# Altium schematic sheet Minigeki v1.SchDoc
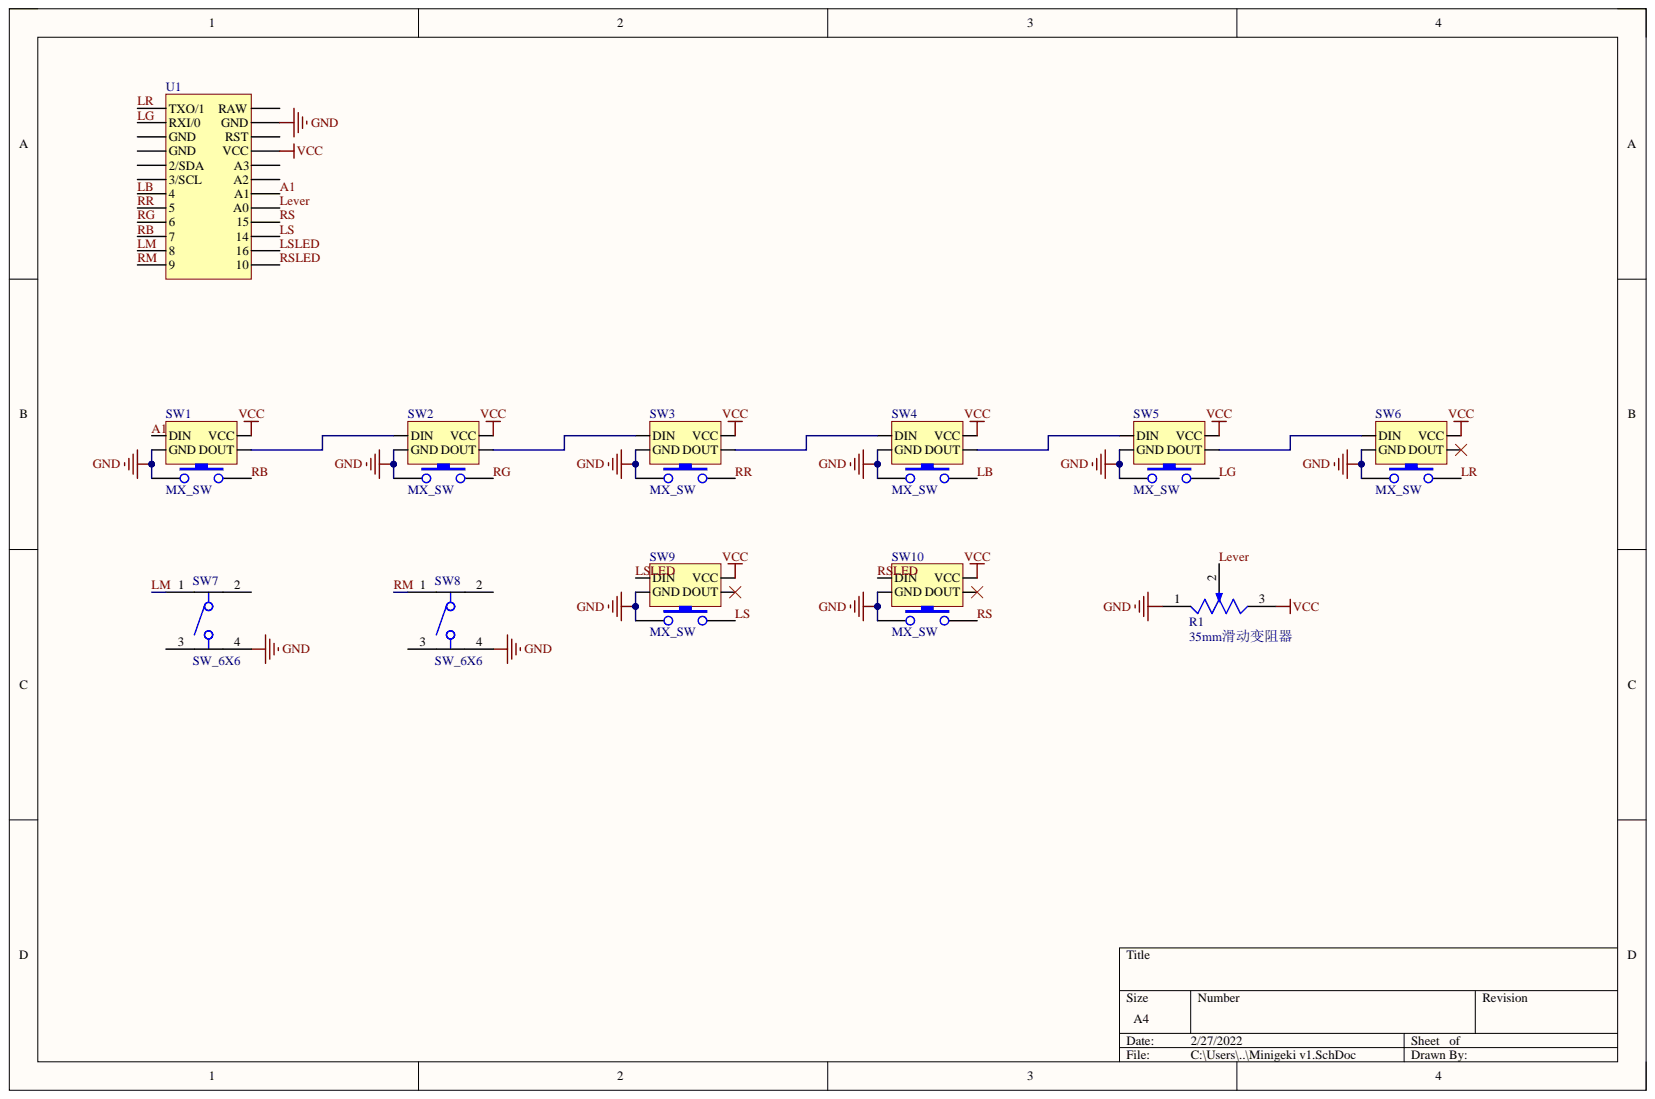
[diagram p0: Minigeki v1.SchDoc]

## Parts
R1  35mm滑动变阻器  [35mm滑动变阻器]
SW1  MX_SW  [SW-1350_CHOC_RGB]
SW2  MX_SW  [SW-1350_CHOC_RGB]
SW3  MX_SW  [SW-1350_CHOC_RGB]
SW4  MX_SW  [SW-1350_CHOC_RGB]
SW5  MX_SW  [SW-1350_CHOC_RGB]
SW6  MX_SW  [SW-1350_CHOC_RGB]
SW7  SW_6X6  [SW_6X6]
SW8  SW_6X6  [SW_6X6]
SW9  MX_SW  [SW-1350_CHOC_RGB]
SW10  MX_SW  [SW-1350_CHOC_RGB]
U1  (no value)  [promicro]  (no BOM)

## Nets
GND: R1.1 SW1.1 SW1.4(GND) SW2.1 SW2.4(GND) SW3.1 SW3.4(GND) SW4.1 SW4.4(GND) SW5.1 SW5.4(GND) SW6.1 SW6.4(GND) SW7.4 SW8.4 SW9.1 SW9.4(GND) SW10.1 SW10.4(GND) U1.14(GND)
VCC: R1.3 SW1.5(VCC) SW2.5(VCC) SW3.5(VCC) SW4.5(VCC) SW5.5(VCC) SW6.5(VCC) SW9.5(VCC) SW10.5(VCC) U1.16(VCC)
A1: SW1.3(DIN) U1.19(A1)
LB: SW4.2 U1.7
Lever: R1.2 U1.20(A0)
LG: SW5.2 U1.2(RXI/0)
LM: SW7.1 U1.11
LR: SW6.2 U1.1(TXO/1)
LS: SW9.2 U1.22
LSLED: SW9.3(DIN) U1.23
RB: SW1.2 U1.10
RG: SW2.2 U1.9
RM: SW8.1 U1.12
RR: SW3.2 U1.8
RS: SW10.2 U1.21
RSLED: SW10.3(DIN) U1.24
NetSW1_6: SW1.6(DOUT) SW2.3(DIN)
NetSW2_6: SW2.6(DOUT) SW3.3(DIN)
NetSW3_6: SW3.6(DOUT) SW4.3(DIN)
NetSW4_6: SW4.6(DOUT) SW5.3(DIN)
NetSW5_6: SW5.6(DOUT) SW6.3(DIN)

Not connected: SW6 6(DOUT); SW7 2 3; SW8 2 3; SW9 6(DOUT); SW10 6(DOUT); U1 3(GND) 4(GND) 5(2/SDA) 6(3/SCL) 13(RAW) 15(RST) 17(A3) 18(A2)

## Library data of the parts
SW1-SW6 SW9 SW10 = MX_SW-1_RGB: without Fixation pins  1-Pole; Component Kind: Standard; Library Name: Minigeki.SchLib
U1 = promicro: Library Name: Minigeki.SchLib
R1 = 35mm滑动变阻器: Component Kind: Standard; Library Name: Minigeki.SchLib
SW7 SW8 = SW_6X6: Component Kind: Standard; Library Name: Minigeki.SchLib
